# Altium schematic sheet B2B_Connectors.SchDoc(U_B2B_Connectors)
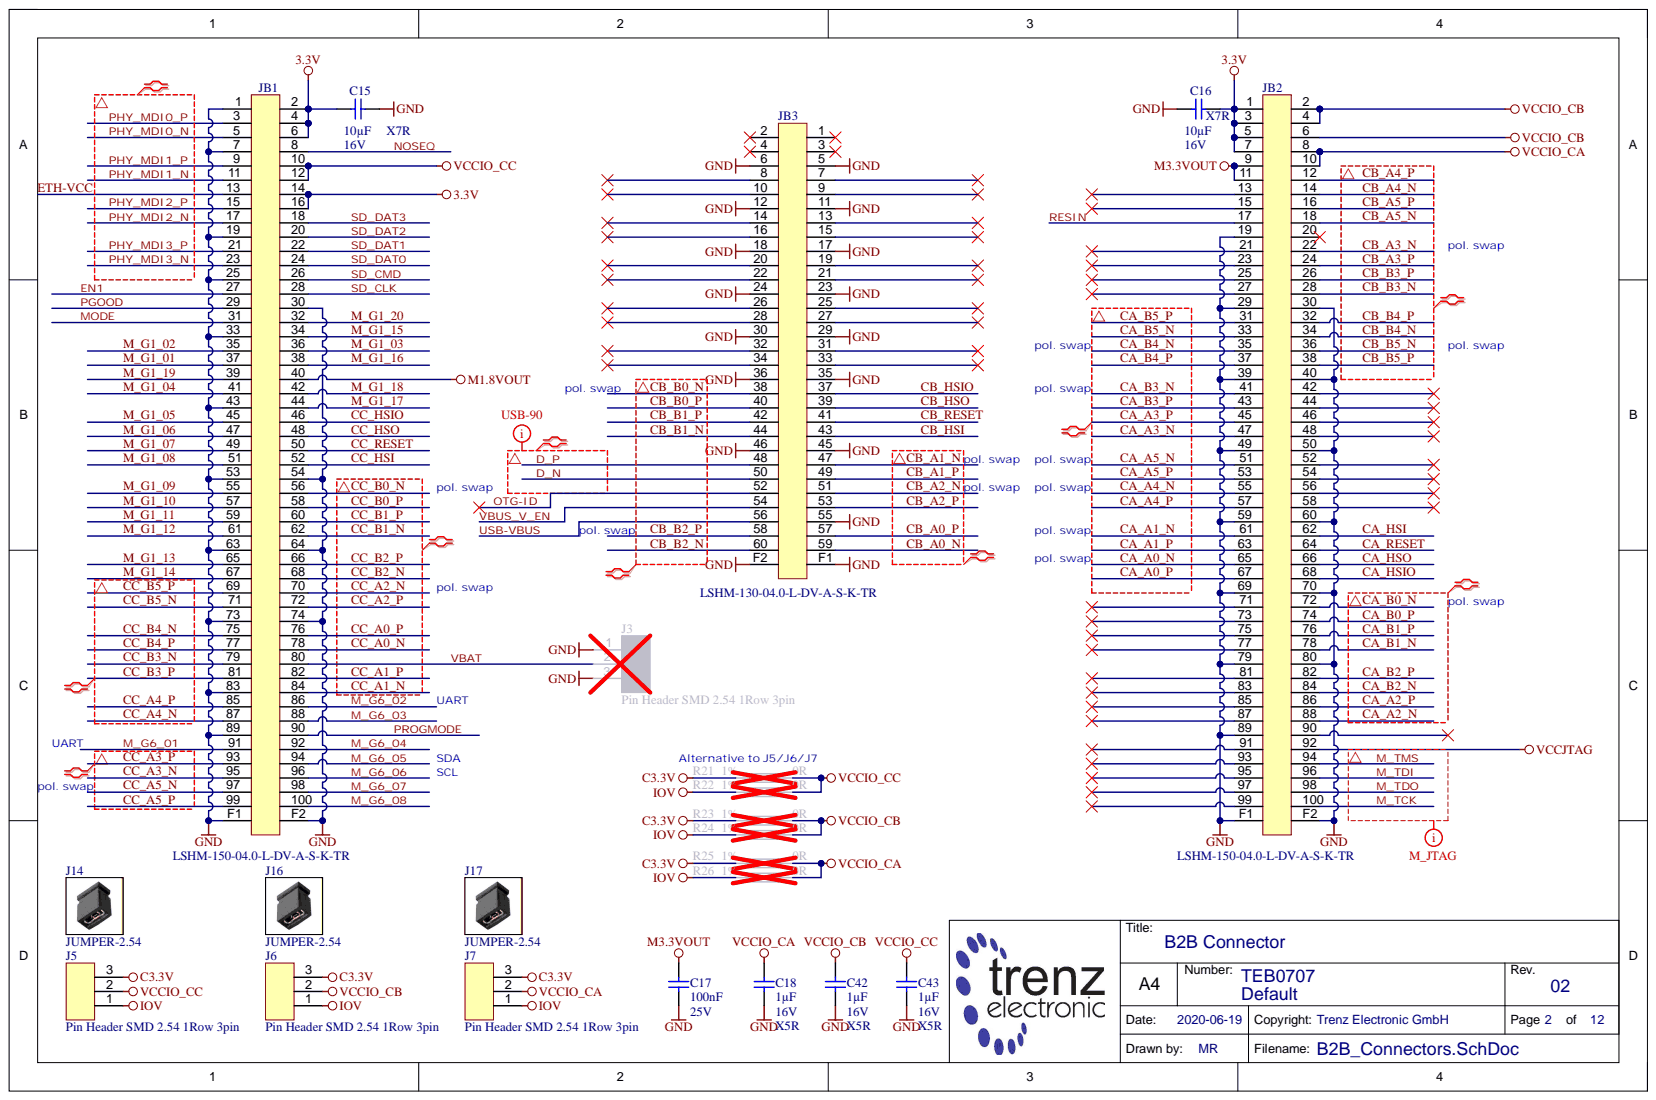
[diagram p0: B2B_Connectors.SchDoc]

## Parts
C15  10µF  Technology: X7R  Voltage: 16V  [CAPC2012X135N]
C16  10µF  Technology: X7R  Voltage: 16V  [CAPC2012X135N]
C17  100nF  Voltage: 25V  [CAPC1005X55N]
C18  1µF  Technology: X5R  Voltage: 16V  [CAPC1005X55N_V]
C42  1µF  Technology: X5R  Voltage: 16V  [CAPC1005X55N_V]
C43  1µF  Technology: X5R  Voltage: 16V  [CAPC1005X55N_V]
J3  Pin Header SMD 2.54 1Row 3pin  [TSM-103-01-F-SV]
J5  Pin Header SMD 2.54 1Row 3pin  [TSM-103-01-F-SV]
J6  Pin Header SMD 2.54 1Row 3pin  [TSM-103-01-F-SV]
J7  Pin Header SMD 2.54 1Row 3pin  [TSM-103-01-F-SV]
J14  JUMPER-2.54  (mechanical)
J16  JUMPER-2.54  (mechanical)
J17  JUMPER-2.54  (mechanical)
JB1  LSHM-150-04.0-L-DV-A-S-K-TR  [LSHM-150-XXX-X-DV-A-X]
JB2  LSHM-150-04.0-L-DV-A-S-K-TR  [LSHM-150-XXX-X-DV-A-X]
JB3  LSHM-130-04.0-L-DV-A-S-K-TR  [LSHM-130-04.0-x-DV-A-S-K-TR]
R21  0R  Tolerance: 1%  [RESC2012X60N]
R22  0R  Tolerance: 1%  [RESC2012X60N]
R23  0R  Tolerance: 1%  [RESC2012X60N]
R24  0R  Tolerance: 1%  [RESC2012X60N]
R25  0R  Tolerance: 1%  [RESC2012X60N]
R26  0R  Tolerance: 1%  [RESC2012X60N]

## Nets
3.3V: C15.2 C16.1 JB1.2 JB1.4 JB1.6 JB1.14 JB1.16 JB2.1 JB2.3 JB2.5 JB2.7
C3.3V: J5.3 J6.3 J7.3 R21.1 R23.1 R25.1
GND: C15.1 C16.2 C17.2 C18.2 C42.2 C43.2 J3.1 J3.3 JB1.1 JB1.7 JB1.19 JB1.25 JB1.30 JB1.33 JB1.43 JB1.53 JB1.54 JB1.63 JB1.64 JB1.73 JB1.74 JB1.83 JB1.89 JB1.F1 JB1.F2 JB2.19 JB2.29 JB2.30 JB2.39 JB2.40 JB2.49 JB2.50 JB2.59 JB2.60 JB2.69 JB2.70 JB2.79 JB2.80 JB2.89 JB2.F1 JB2.F2 JB3.5 JB3.6 JB3.11 JB3.12 JB3.17 JB3.18 JB3.23 JB3.24 JB3.29 JB3.30 JB3.35 JB3.36 JB3.45 JB3.46 JB3.55 JB3.F1 JB3.F2
IOV: J5.1 J6.1 J7.1 R22.1 R24.1 R26.1
M1.8VOUT: JB1.40
M3.3VOUT: C17.1 JB2.9 JB2.11
VCCIO_CA: C18.1 J7.2 JB2.8 JB2.10 R25.2 R26.2
VCCIO_CB: C42.1 J6.2 JB2.2 JB2.4 JB2.6 R23.2 R24.2
VCCIO_CC: C43.1 J5.2 JB1.10 JB1.12 R21.2 R22.2
VCCJTAG: JB2.92
CA_A0_N: JB2.65
CA_A0_P: JB2.67
CA_A1_N: JB2.61
CA_A1_P: JB2.63
CA_A2_N: JB2.88
CA_A2_P: JB2.86
CA_A3_N: JB2.47
CA_A3_P: JB2.45
CA_A4_N: JB2.55
CA_A4_P: JB2.57
CA_A5_N: JB2.51
CA_A5_P: JB2.53
CA_B0_N: JB2.72
CA_B0_P: JB2.74
CA_B1_N: JB2.78
CA_B1_P: JB2.76
CA_B2_N: JB2.84
CA_B2_P: JB2.82
CA_B3_N: JB2.41
CA_B3_P: JB2.43
CA_B4_N: JB2.35
CA_B4_P: JB2.37
CA_B5_N: JB2.33
CA_B5_P: JB2.31
CA_HSI: JB2.62
CA_HSIO: JB2.68
CA_HSO: JB2.66
CA_RESET: JB2.64
CB_A0_N: JB3.59
CB_A0_P: JB3.57
CB_A1_N: JB3.47
CB_A1_P: JB3.49
CB_A2_N: JB3.51
CB_A2_P: JB3.53
CB_A3_N: JB2.22
CB_A3_P: JB2.24
CB_A4_N: JB2.14
CB_A4_P: JB2.12
CB_A5_N: JB2.18
CB_A5_P: JB2.16
CB_B0_N: JB3.38
CB_B0_P: JB3.40
CB_B1_N: JB3.44
CB_B1_P: JB3.42
CB_B2_N: JB3.60
CB_B2_P: JB3.58
CB_B3_N: JB2.28
CB_B3_P: JB2.26
CB_B4_N: JB2.34
CB_B4_P: JB2.32
CB_B5_N: JB2.36
CB_B5_P: JB2.38
CB_HSI: JB3.43
CB_HSIO: JB3.37
CB_HSO: JB3.39
CB_RESET: JB3.41
CC_A0_N: JB1.78
CC_A0_P: JB1.76
CC_A1_N: JB1.84
CC_A1_P: JB1.82
CC_A2_N: JB1.70
CC_A2_P: JB1.72
CC_A3_N: JB1.95
CC_A3_P: JB1.93
CC_A4_N: JB1.87
CC_A4_P: JB1.85
CC_A5_N: JB1.97
CC_A5_P: JB1.99
CC_B0_N: JB1.56
CC_B0_P: JB1.58
CC_B1_N: JB1.62
CC_B1_P: JB1.60
CC_B2_N: JB1.68
CC_B2_P: JB1.66
CC_B3_N: JB1.79
CC_B3_P: JB1.81
CC_B4_N: JB1.75
CC_B4_P: JB1.77
CC_B5_N: JB1.71
CC_B5_P: JB1.69
CC_HSI: JB1.52
CC_HSIO: JB1.46
CC_HSO: JB1.48
CC_RESET: JB1.50
D_N: JB3.50
D_P: JB3.48
EN1: JB1.27
ETH-VCC: JB1.13
M_G1_01: JB1.37
M_G1_02: JB1.35
M_G1_03: JB1.36
M_G1_04: JB1.41
M_G1_05: JB1.45
M_G1_06: JB1.47
M_G1_07: JB1.49
M_G1_08: JB1.51
M_G1_09: JB1.55
M_G1_10: JB1.57
M_G1_11: JB1.59
M_G1_12: JB1.61
M_G1_13: JB1.65
M_G1_14: JB1.67
M_G1_15: JB1.34
M_G1_16: JB1.38
M_G1_17: JB1.44
M_G1_18: JB1.42
M_G1_19: JB1.39
M_G1_20: JB1.32
M_G6_01: JB1.91
M_G6_02: JB1.86
M_G6_03: JB1.88
M_G6_04: JB1.92
M_G6_05: JB1.94
M_G6_06: JB1.96
M_G6_07: JB1.98
M_G6_08: JB1.100
M_TCK: JB2.100
M_TDI: JB2.96
M_TDO: JB2.98
M_TMS: JB2.94
MODE: JB1.31
NOSEQ: JB1.8
OTG-ID: JB3.52
PGOOD: JB1.29
PHY_MDI0_N: JB1.5
PHY_MDI0_P: JB1.3
PHY_MDI1_N: JB1.11
PHY_MDI1_P: JB1.9
PHY_MDI2_N: JB1.17
PHY_MDI2_P: JB1.15
PHY_MDI3_N: JB1.23
PHY_MDI3_P: JB1.21
PROGMODE: JB1.90
RESIN: JB2.17
SD_CLK: JB1.28
SD_CMD: JB1.26
SD_DAT0: JB1.24
SD_DAT1: JB1.22
SD_DAT2: JB1.20
SD_DAT3: JB1.18
USB-VBUS: JB3.56
VBAT: J3.2 JB1.80
VBUS_V_EN: JB3.54

Not connected: JB2 13 15 20 21 23 25 27 42 44 46 48 52 54 56 58 71 73 75 77 81 83 85 87 90 91 93 95 97 99; JB3 1 2 3 4 7 8 9 10 13 14 15 16 19 20 21 22 25 26 27 28 31 32 33 34

## Library data of the parts
C15 C16 = 24380: KON 10µF 16V X7R 10% SMD BF 2012 (0805); Database Table Name: C_2012_0805; Mixed: SMT; Preferred: Yes; Temperature-Range: -55/+125; Value: 10u; AN: 24380; Case: 2012 (0805); Component Kind: Standard; Library Name: TE.DbLib; Tolerance: 10%
C17 = 22472: KON 100nF 25V X5R ±10% SMD BF 1005 (0402); Database Table Name: C_1005_0402; Mixed: SMT; Preferred: Yes; Temperature-Range: -55/+85; Value: 100n; AN: 22472; Case: 1005 (0402); Component Kind: Standard; Library Name: TE.DbLib; Technology: X5R
C18 C42 C43 = 26579: KON 1µF 16V X5R 10% SMD BF 1005 (0402); Database Table Name: C_1005_0402; Mixed: SMT; Temperature-Range: -55/+85; Value: 1u; AN: 26579; Case: 1005 (0402); Component Kind: Standard; Library Name: TE.DbLib; Tolerance: 10%
J3 J5-J7 = 29447: Stiftleiste 1-reihig, 3pol. SMD; Database Table Name: CON_HEADER; Extra: 2,54mm, 5.84mm pin length, gold flash, PP pad; H-ART: TSM-103-01-F-SV-P-TR; Mixed: SMT; Temperature-Range: -55/+125; AN: 29447; Case: TSM; Component Kind: Standard; Library Name: TE.DbLib; HelpURL: b:\AD\adlib\datasheet\Samtec_TSM\
J14 J16 J17 = 20996: Jumper 2,54mm Raster, Schwarz offen; Database Table Name: CON_HEADER; AN: 20996; Library Name: TE.DbLib
JB1 JB2 = 23838: Samtec Razor Beam REF-189016-02, LF-04.0, 100 Pins, für alle 4x5 SoMs; Database Table Name: CON_B2B; Mixed: SMT; Preferred: Yes; AN: 23838; Component Kind: Standard; Extra: LSHM-150-04.0-L-DV-A-S-K-TR; H-ART: REF-189016-02; Library Name: TE.DbLib; Trenz-Stock: Yes; HelpURL: B:\AD\adlib\datasheet\LSHM-1XX-XX-XXX-DV-A
JB3 = 24903: Samtec Razor Beam REF-189017-02, LF-04.0, 60 Pins, für alle 4x5 SoMs; Database Table Name: CON_B2B; Mixed: SMT; Trenz-Stock: Yes; AN: 24903; Component Kind: Standard; Extra: LSHM-130-04.0-L-DV-A-S-K-TR; H-ART: REF-189017-02; Library Name: TE.DbLib; HelpURL: B:\AD\adlib\datasheet\LSHM-1XX-XX-XXX-DV-A
R21-R26 = 23415: WID SMD 0R 0.125W 2A Brücke; Database Table Name: R_2012_0805; Extra: BF 2012 (0805); Mixed: SMT; Preferred: Yes; Value: 0; AN: 23415; Case: 2012 (0805); Component Kind: Standard; Library Name: TE.DbLib; Technology: TK100
